# Altium schematic sheet （7）USB & GBE & SD.Sch
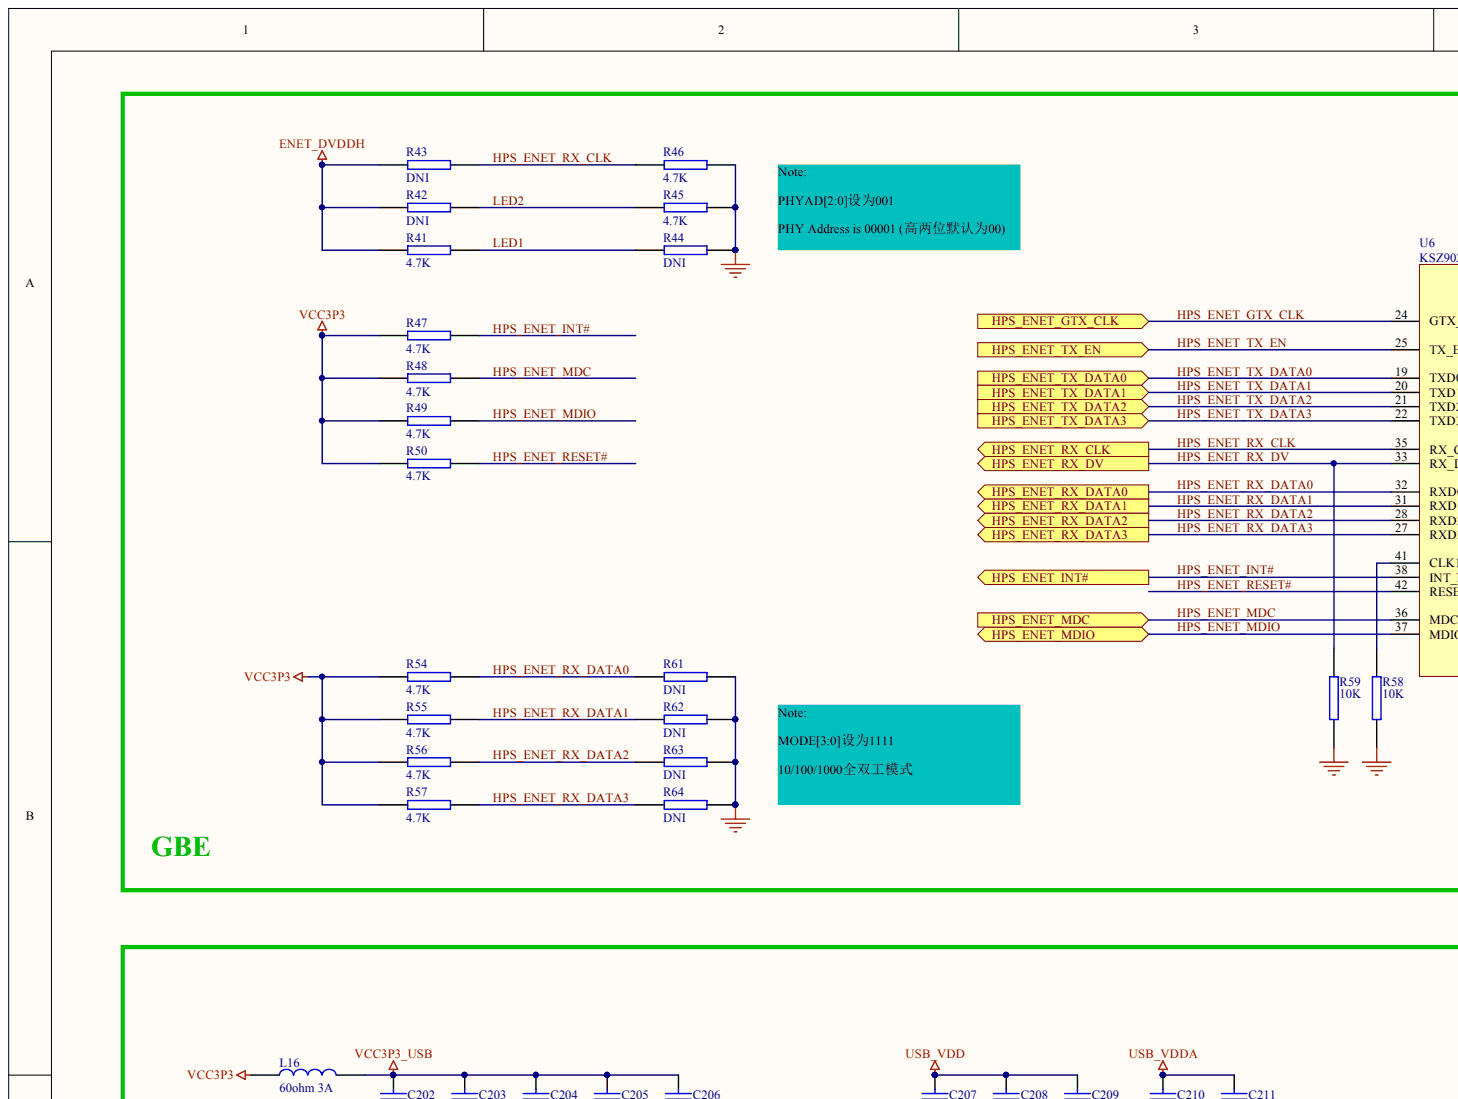
[diagram p0: （7）USB & GBE & SD.Sch, top left]
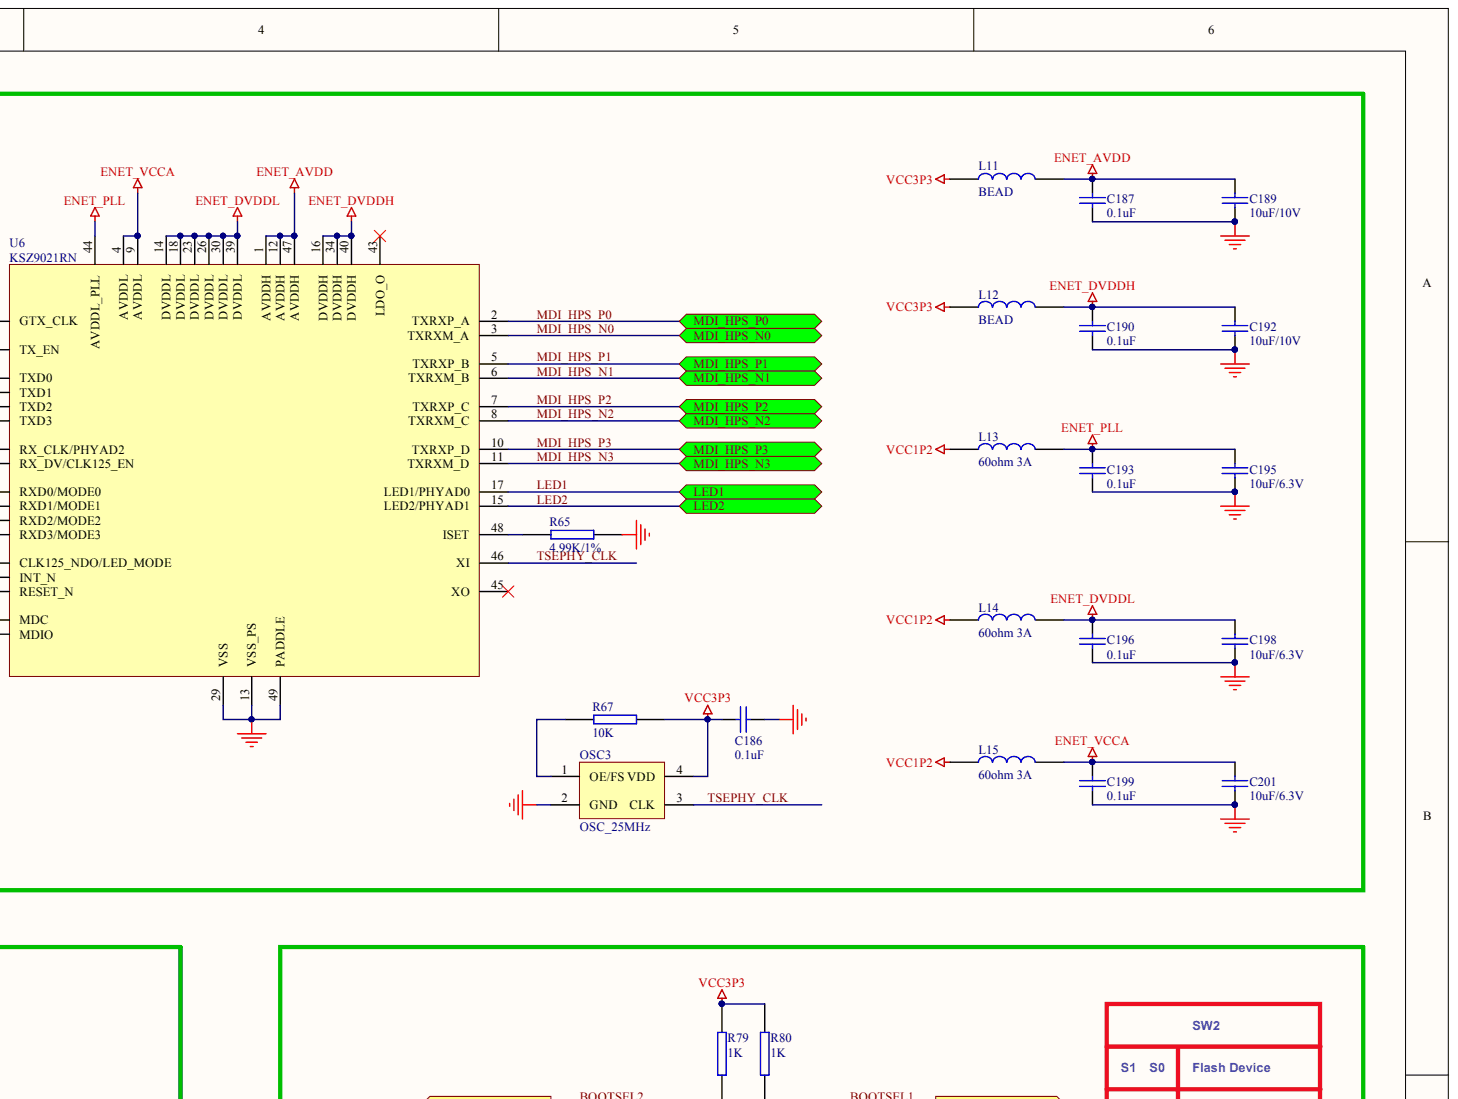
[diagram p1: （7）USB & GBE & SD.Sch, top right]
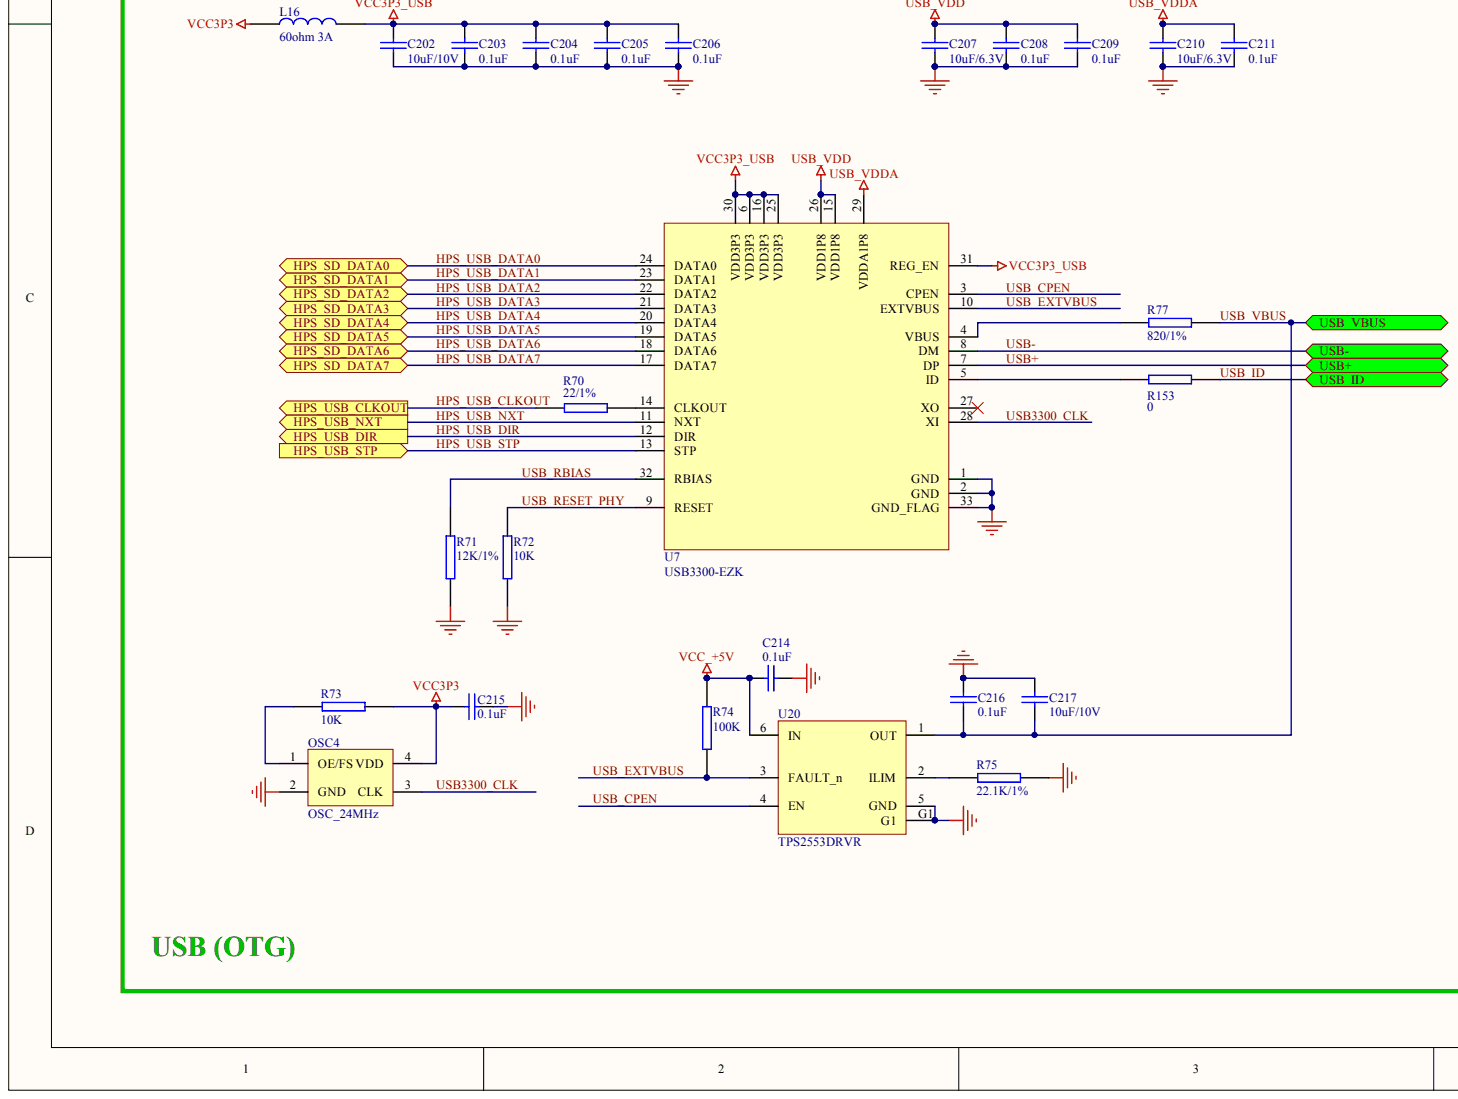
[diagram p2: （7）USB & GBE & SD.Sch, bottom left]
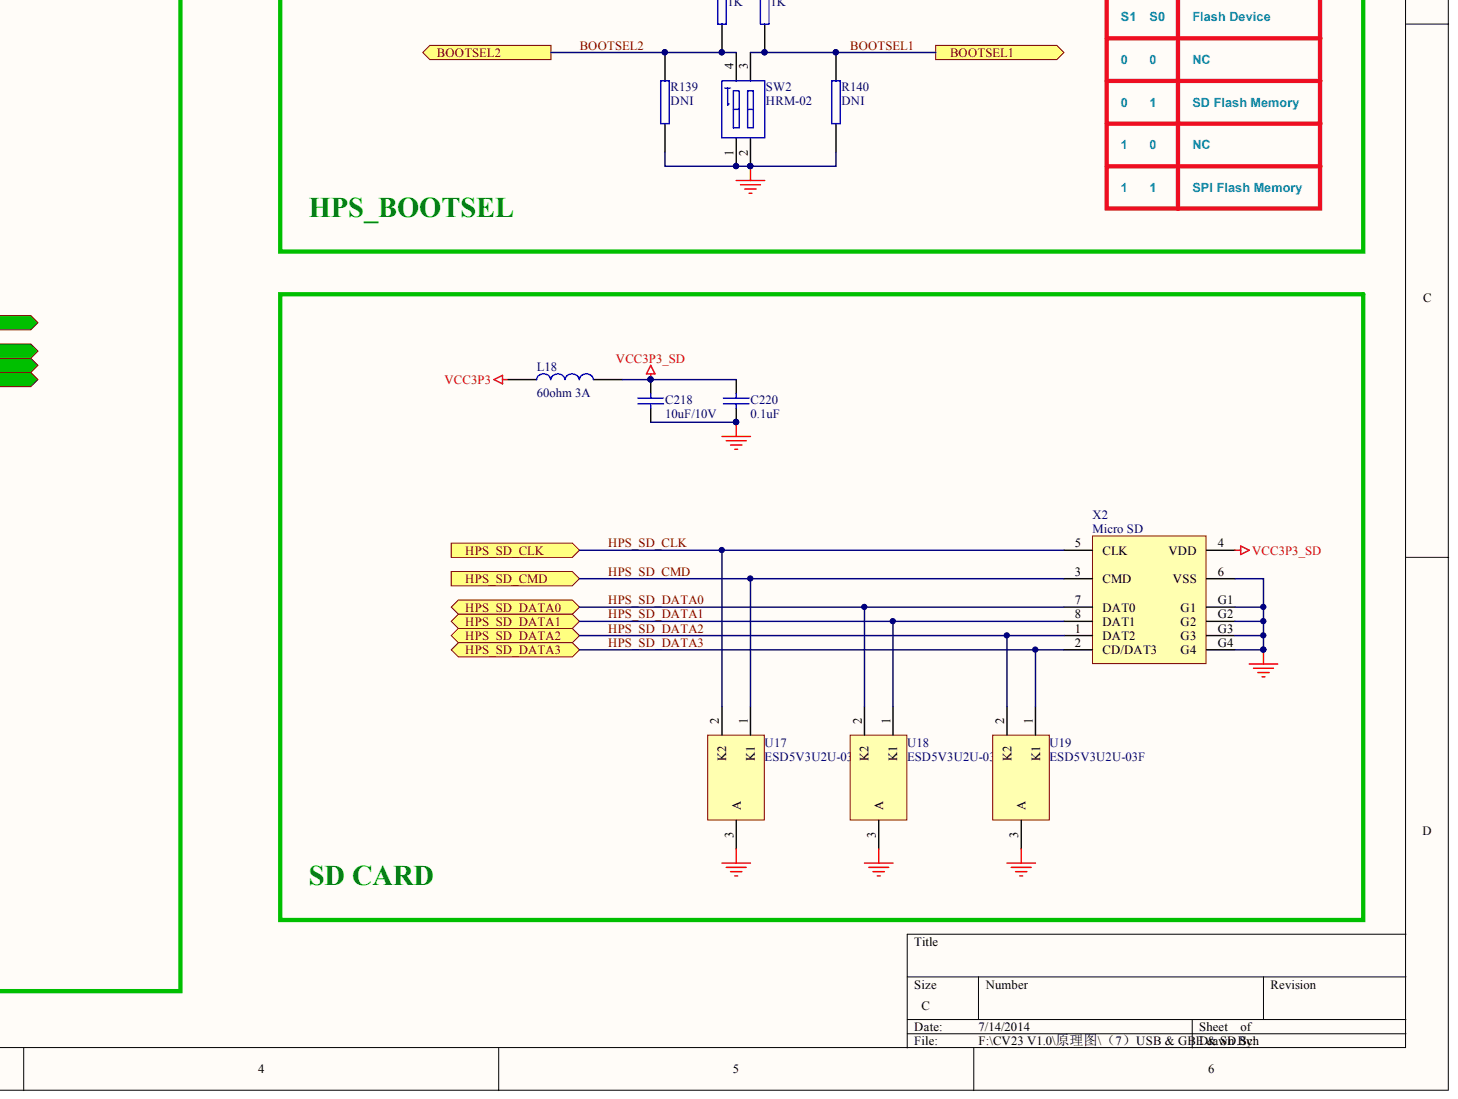
[diagram p3: （7）USB & GBE & SD.Sch, bottom right]

## Parts
C186  0.1uF
C187  0.1uF
C189  10uF/10V
C190  0.1uF
C192  10uF/10V
C193  0.1uF
C195  10uF/6.3V
C196  0.1uF
C198  10uF/6.3V
C199  0.1uF
C201  10uF/6.3V
C202  10uF/10V
C203  0.1uF
C204  0.1uF
C205  0.1uF
C206  0.1uF
C207  10uF/6.3V
C208  0.1uF
C209  0.1uF
C210  10uF/6.3V
C211  0.1uF
C214  0.1uF
C215  0.1uF
C216  0.1uF
C217  10uF/10V
C218  10uF/10V
C220  0.1uF
L11  BEAD
L12  BEAD
L13  60ohm 3A
L14  60ohm 3A
L15  60ohm 3A
L16  60ohm 3A
L18  60ohm 3A
OSC3  OSC_25MHz
OSC4  OSC_24MHz
R41  4.7K
R42  DNI
R43  DNI
R44  DNI
R45  4.7K
R46  4.7K
R47  4.7K
R48  4.7K
R49  4.7K
R50  4.7K
R54  4.7K
R55  4.7K
R56  4.7K
R57  4.7K
R58  10K
R59  10K
R61  DNI
R62  DNI
R63  DNI
R64  DNI
R65  4.99K/1%
R67  10K
R70  22/1%
R71  12K/1%
R72  10K
R73  10K
R74  100K
R75  22.1K/1%
R77  820/1%
R79  1K
R80  1K
R139  DNI
R140  DNI
R153  0
SW2  HRM-02
U6  KSZ9021RN
U7  USB3300-EZK
U17  ESD5V3U2U-03F
U18  ESD5V3U2U-03F
U19  ESD5V3U2U-03F
U20  TPS2553DRVR
X2  Micro SD

## Ports
BOOTSEL1, BOOTSEL2, HPS_ENET_GTX_CLK, HPS_ENET_INT#, HPS_ENET_MDC, HPS_ENET_MDIO, HPS_ENET_RX_CLK, HPS_ENET_RX_DATA0, HPS_ENET_RX_DATA1, HPS_ENET_RX_DATA2, HPS_ENET_RX_DATA3, HPS_ENET_RX_DV, HPS_ENET_TX_DATA0, HPS_ENET_TX_DATA1, HPS_ENET_TX_DATA2, HPS_ENET_TX_DATA3, HPS_ENET_TX_EN, HPS_SD_CLK, HPS_SD_CMD, HPS_SD_DATA0, HPS_SD_DATA1, HPS_SD_DATA2, HPS_SD_DATA3, HPS_SD_DATA4, HPS_SD_DATA5, HPS_SD_DATA6, HPS_SD_DATA7, HPS_USB_CLKOUT, HPS_USB_DIR, HPS_USB_NXT, HPS_USB_STP, LED1, LED2, MDI_HPS_N0, MDI_HPS_N1, MDI_HPS_N2, MDI_HPS_N3, MDI_HPS_P0, MDI_HPS_P1, MDI_HPS_P2, MDI_HPS_P3, USB+, USB-, USB_ID, USB_VBUS

## Nets
ENET_AVDD: C187.1 C189.1 L11.2 U6.1(AVDDH) U6.12(AVDDH) U6.47(AVDDH)
ENET_DVDDH: C190.1 C192.1 L12.2 R41.1 R42.1 R43.1 U6.16(DVDDH) U6.34(DVDDH) U6.40(DVDDH)
ENET_DVDDL: C196.1 C198.1 L14.2 U6.14(DVDDL) U6.18(DVDDL) U6.23(DVDDL) U6.26(DVDDL) U6.30(DVDDL) U6.39(DVDDL)
ENET_PLL: C193.1 C195.1 L13.2 U6.44(AVDDL_PLL)
ENET_VCCA: C199.1 C201.1 L15.2 U6.4(AVDDL) U6.9(AVDDL)
GND: C186.2 C187.2 C189.2 C190.2 C192.2 C193.2 C195.2 C196.2 C198.2 C199.2 C201.2 C202.2 C203.2 C204.2 C205.2 C206.2 C207.2 C208.2 C209.2 C210.2 C211.2 C214.2 C215.2 C216.2 C217.2 C218.2 C220.2 OSC3.2(GND) OSC4.2(GND) R44.2 R45.2 R46.2 R58.1 R59.1 R61.2 R62.2 R63.2 R64.2 R65.1 R71.1 R72.1 R75.1 R139.1 R140.1 SW2.1 SW2.2 U6.13(VSS_PS) U6.29(VSS) U6.49(PADDLE) U7.1(GND) U7.2(GND) U7.33(GND_FLAG) U17.3 U18.3 U19.3 U20.5(GND) U20.G1 X2.6(VSS) X2.G1 X2.G2 X2.G3 X2.G4
USB_VDD: C207.1 C208.1 C209.1 U7.15(VDD1P8) U7.26(VDD1P8)
USB_VDDA: C210.1 C211.1 U7.29(VDDA1P8)
VCC1P2: L13.1 L14.1 L15.1
VCC3P3: C186.1 C215.1 L11.1 L12.1 L16.1 L18.1 OSC3.4(VDD) OSC4.4(VDD) R47.1 R48.1 R49.1 R50.1 R54.1 R55.1 R56.1 R57.1 R67.1 R73.1 R79.1 R80.1
VCC3P3_SD: C218.1 C220.1 L18.2 X2.4(VDD)
VCC3P3_USB: C202.1 C203.1 C204.1 C205.1 C206.1 L16.2 U7.6(VDD3P3) U7.16(VDD3P3) U7.25(VDD3P3) U7.30(VDD3P3) U7.31(REG_EN)
VCC_+5V: C214.1 R74.2 U20.6(IN)
BOOTSEL1: R80.2 R140.2 SW2.3 ; port BOOTSEL1
BOOTSEL2: R79.2 R139.2 SW2.4 ; port BOOTSEL2
HPS_ENET_GTX_CLK: U6.24(GTX_CLK) ; port HPS_ENET_GTX_CLK
HPS_ENET_INT#: R47.2 U6.38(INT_N) ; port HPS_ENET_INT#
HPS_ENET_MDC: R48.2 U6.36(MDC) ; port HPS_ENET_MDC
HPS_ENET_MDIO: R49.2 U6.37(MDIO) ; port HPS_ENET_MDIO
HPS_ENET_RESET#: R50.2 U6.42(RESET_N)
HPS_ENET_RX_CLK: R43.2 R46.1 U6.35(RX_CLK/PHYAD2) ; port HPS_ENET_RX_CLK
HPS_ENET_RX_DATA0: R54.2 R61.1 U6.32(RXD0/MODE0) ; port HPS_ENET_RX_DATA0
HPS_ENET_RX_DATA1: R55.2 R62.1 U6.31(RXD1/MODE1) ; port HPS_ENET_RX_DATA1
HPS_ENET_RX_DATA2: R56.2 R63.1 U6.28(RXD2/MODE2) ; port HPS_ENET_RX_DATA2
HPS_ENET_RX_DATA3: R57.2 R64.1 U6.27(RXD3/MODE3) ; port HPS_ENET_RX_DATA3
HPS_ENET_RX_DV: R59.2 U6.33(RX_DV/CLK125_EN) ; port HPS_ENET_RX_DV
HPS_ENET_TX_DATA0: U6.19(TXD0) ; port HPS_ENET_TX_DATA0
HPS_ENET_TX_DATA1: U6.20(TXD1) ; port HPS_ENET_TX_DATA1
HPS_ENET_TX_DATA2: U6.21(TXD2) ; port HPS_ENET_TX_DATA2
HPS_ENET_TX_DATA3: U6.22(TXD3) ; port HPS_ENET_TX_DATA3
HPS_ENET_TX_EN: U6.25(TX_EN) ; port HPS_ENET_TX_EN
HPS_SD_CLK: U17.2 X2.5(CLK) ; port HPS_SD_CLK
HPS_SD_CMD: U17.1 X2.3(CMD) ; port HPS_SD_CMD
HPS_SD_DATA0: U7.24(DATA0) U18.2 X2.7(DAT0) ; port HPS_SD_DATA0
HPS_SD_DATA1: U7.23(DATA1) U18.1 X2.8(DAT1) ; port HPS_SD_DATA1
HPS_SD_DATA2: U7.22(DATA2) U19.2 X2.1(DAT2) ; port HPS_SD_DATA2
HPS_SD_DATA3: U7.21(DATA3) U19.1 X2.2(CD/DAT3) ; port HPS_SD_DATA3
HPS_USB_CLKOUT: R70.2 ; port HPS_USB_CLKOUT
HPS_USB_DATA4: U7.20(DATA4) ; port HPS_SD_DATA4
HPS_USB_DATA5: U7.19(DATA5) ; port HPS_SD_DATA5
HPS_USB_DATA6: U7.18(DATA6) ; port HPS_SD_DATA6
HPS_USB_DATA7: U7.17(DATA7) ; port HPS_SD_DATA7
HPS_USB_DIR: U7.12(DIR) ; port HPS_USB_DIR
HPS_USB_NXT: U7.11(NXT) ; port HPS_USB_NXT
HPS_USB_STP: U7.13(STP) ; port HPS_USB_STP
LED1: R41.2 R44.1 U6.17(LED1/PHYAD0) ; port LED1
LED2: R42.2 R45.1 U6.15(LED2/PHYAD1) ; port LED2
MDI_HPS_N0: U6.3(TXRXM_A) ; port MDI_HPS_N0
MDI_HPS_N1: U6.6(TXRXM_B) ; port MDI_HPS_N1
MDI_HPS_N2: U6.8(TXRXM_C) ; port MDI_HPS_N2
MDI_HPS_N3: U6.11(TXRXM_D) ; port MDI_HPS_N3
MDI_HPS_P0: U6.2(TXRXP_A) ; port MDI_HPS_P0
MDI_HPS_P1: U6.5(TXRXP_B) ; port MDI_HPS_P1
MDI_HPS_P2: U6.7(TXRXP_C) ; port MDI_HPS_P2
MDI_HPS_P3: U6.10(TXRXP_D) ; port MDI_HPS_P3
TSEPHY_CLK: OSC3.3(CLK) U6.46(XI)
USB3300_CLK: OSC4.3(CLK) U7.28(XI)
USB+: U7.7(DP) ; port USB+
USB-: U7.8(DM) ; port USB-
USB_CPEN: U7.3(CPEN) U20.4(EN)
USB_EXTVBUS: R74.1 U7.10(EXTVBUS) U20.3(FAULT_n)
USB_ID: R153.1 ; port USB_ID
USB_RBIAS: R71.2 U7.32(RBIAS)
USB_RESET_PHY: R72.2 U7.9(RESET)
USB_VBUS: C216.1 C217.1 R77.1 U20.1(OUT) ; port USB_VBUS
NetOSC3_1: OSC3.1(OE/FS) R67.2
NetOSC4_1: OSC4.1(OE/FS) R73.2
NetR58_2: R58.2 U6.41(CLK125_NDO/LED_MODE)
NetR65_2: R65.2 U6.48(ISET)
NetR70_1: R70.1 U7.14(CLKOUT)
NetR75_2: R75.2 U20.2(ILIM)
NetR77_2: R77.2 U7.4(VBUS)
NetR153_2: R153.2 U7.5(ID)

Not connected: U6 43(LDO_O) 45(XO); U7 27(XO)
